# Altium schematic sheet 07-KSZ9031_1G_BASET_XCVR.SchDoc(07-KSZ9031_1G_BASET_XCVR)
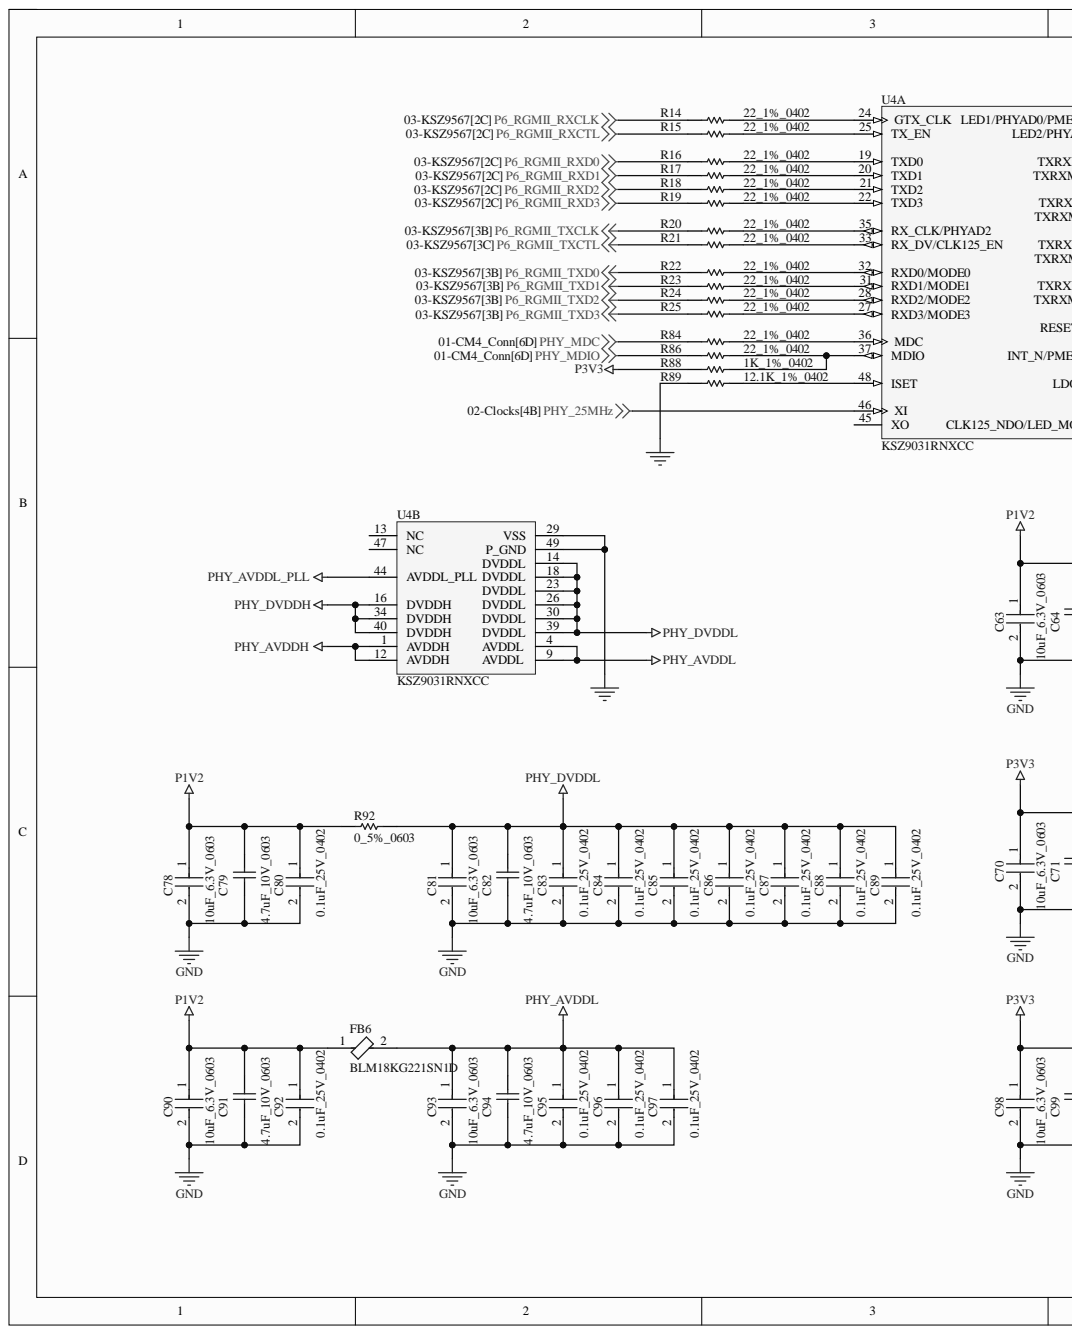
[diagram p0: 07-KSZ9031_1G_BASET_XCVR.SchDoc, left]
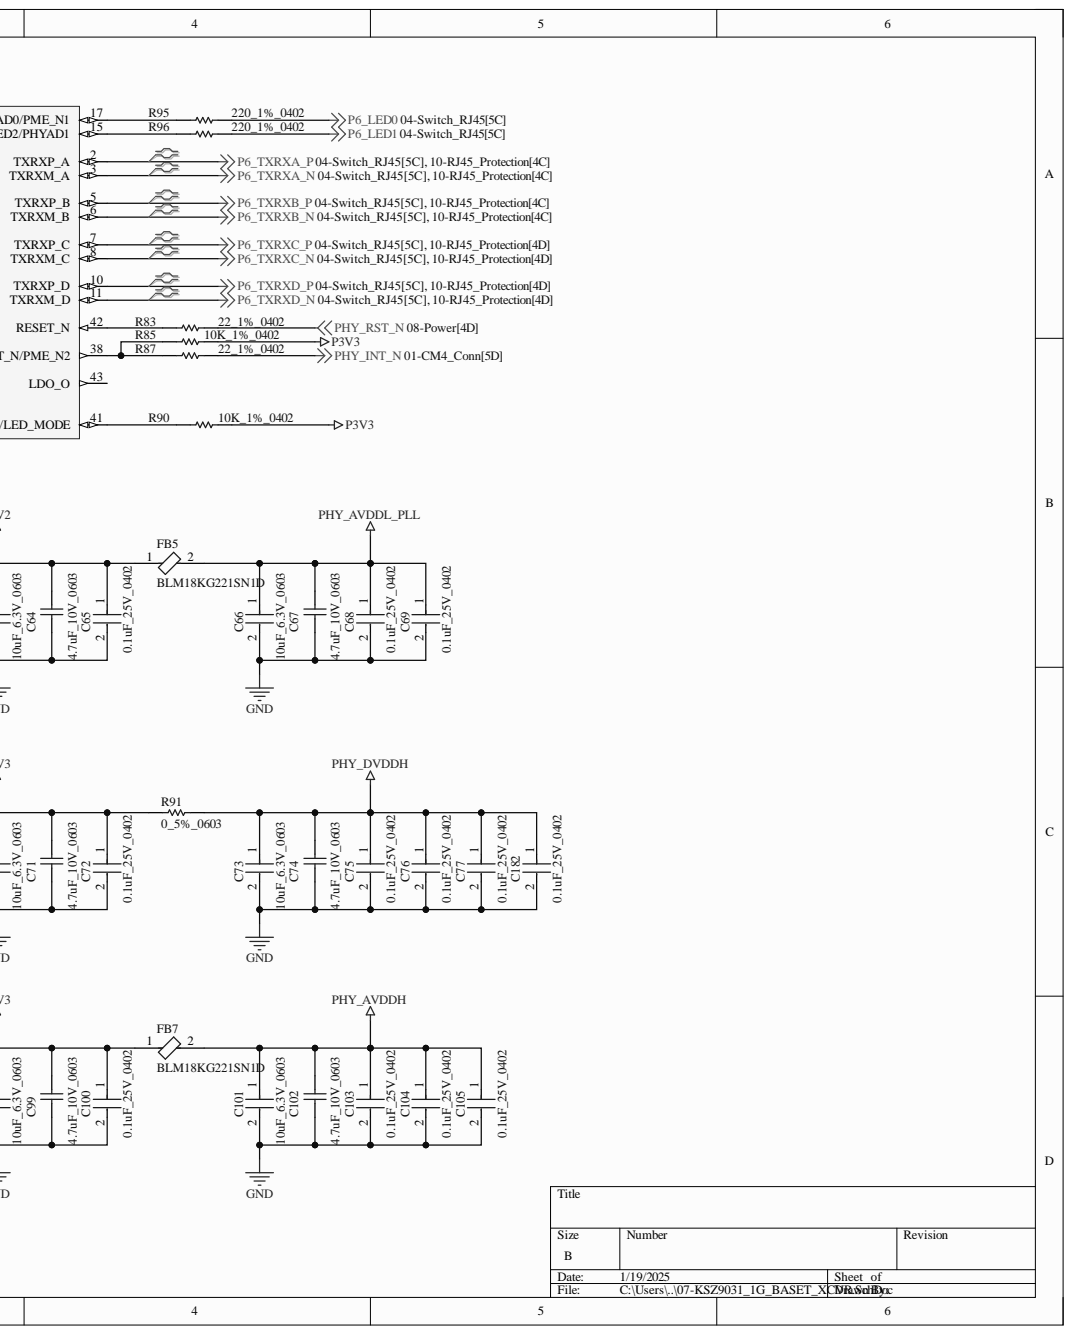
[diagram p1: 07-KSZ9031_1G_BASET_XCVR.SchDoc, right]

## Parts
C63  10uF_6.3V_0603  [FP-C0603C106M9PACTU-MFG]
C64  4.7uF_10V_0603  [CAPC1608X87X35NL15T15]
C65  0.1uF_25V_0402  [FP-0402-L_1_0_1-W_0_5_0_1-IPC_C]
C66  10uF_6.3V_0603  [FP-C0603C106M9PACTU-MFG]
C67  4.7uF_10V_0603  [CAPC1608X87X35NL15T15]
C68  0.1uF_25V_0402  [FP-0402-L_1_0_1-W_0_5_0_1-IPC_C]
C69  0.1uF_25V_0402  [FP-0402-L_1_0_1-W_0_5_0_1-IPC_C]
C70  10uF_6.3V_0603  [FP-C0603C106M9PACTU-MFG]
C71  4.7uF_10V_0603  [CAPC1608X87X35NL15T15]
C72  0.1uF_25V_0402  [FP-0402-L_1_0_1-W_0_5_0_1-IPC_C]
C73  10uF_6.3V_0603  [FP-C0603C106M9PACTU-MFG]
C74  4.7uF_10V_0603  [CAPC1608X87X35NL15T15]
C75  0.1uF_25V_0402  [FP-0402-L_1_0_1-W_0_5_0_1-IPC_C]
C76  0.1uF_25V_0402  [FP-0402-L_1_0_1-W_0_5_0_1-IPC_C]
C77  0.1uF_25V_0402  [FP-0402-L_1_0_1-W_0_5_0_1-IPC_C]
C78  10uF_6.3V_0603  [FP-C0603C106M9PACTU-MFG]
C79  4.7uF_10V_0603  [CAPC1608X87X35NL15T15]
C80  0.1uF_25V_0402  [FP-0402-L_1_0_1-W_0_5_0_1-IPC_C]
C81  10uF_6.3V_0603  [FP-C0603C106M9PACTU-MFG]
C82  4.7uF_10V_0603  [CAPC1608X87X35NL15T15]
C83  0.1uF_25V_0402  [FP-0402-L_1_0_1-W_0_5_0_1-IPC_C]
C84  0.1uF_25V_0402  [FP-0402-L_1_0_1-W_0_5_0_1-IPC_C]
C85  0.1uF_25V_0402  [FP-0402-L_1_0_1-W_0_5_0_1-IPC_C]
C86  0.1uF_25V_0402  [FP-0402-L_1_0_1-W_0_5_0_1-IPC_C]
C87  0.1uF_25V_0402  [FP-0402-L_1_0_1-W_0_5_0_1-IPC_C]
C88  0.1uF_25V_0402  [FP-0402-L_1_0_1-W_0_5_0_1-IPC_C]
C89  0.1uF_25V_0402  [FP-0402-L_1_0_1-W_0_5_0_1-IPC_C]
C90  10uF_6.3V_0603  [FP-C0603C106M9PACTU-MFG]
C91  4.7uF_10V_0603  [CAPC1608X87X35NL15T15]
C92  0.1uF_25V_0402  [FP-0402-L_1_0_1-W_0_5_0_1-IPC_C]
C93  10uF_6.3V_0603  [FP-C0603C106M9PACTU-MFG]
C94  4.7uF_10V_0603  [CAPC1608X87X35NL15T15]
C95  0.1uF_25V_0402  [FP-0402-L_1_0_1-W_0_5_0_1-IPC_C]
C96  0.1uF_25V_0402  [FP-0402-L_1_0_1-W_0_5_0_1-IPC_C]
C97  0.1uF_25V_0402  [FP-0402-L_1_0_1-W_0_5_0_1-IPC_C]
C98  10uF_6.3V_0603  [FP-C0603C106M9PACTU-MFG]
C99  4.7uF_10V_0603  [CAPC1608X87X35NL15T15]
C100  0.1uF_25V_0402  [FP-0402-L_1_0_1-W_0_5_0_1-IPC_C]
C101  10uF_6.3V_0603  [FP-C0603C106M9PACTU-MFG]
C102  4.7uF_10V_0603  [CAPC1608X87X35NL15T15]
C103  0.1uF_25V_0402  [FP-0402-L_1_0_1-W_0_5_0_1-IPC_C]
C104  0.1uF_25V_0402  [FP-0402-L_1_0_1-W_0_5_0_1-IPC_C]
C105  0.1uF_25V_0402  [FP-0402-L_1_0_1-W_0_5_0_1-IPC_C]
C182  0.1uF_25V_0402  [FP-0402-L_1_0_1-W_0_5_0_1-IPC_C]
FB5  BLM18KG221SN1D  [FP-BLM18-0_15-t0_8-IPC_B]
FB6  BLM18KG221SN1D  [FP-BLM18-0_15-t0_8-IPC_B]
FB7  BLM18KG221SN1D  [FP-BLM18-0_15-t0_8-IPC_B]
R14  22_1%_0402  [RESC1005X40X25ML05T10]
R15  22_1%_0402  [RESC1005X40X25ML05T10]
R16  22_1%_0402  [RESC1005X40X25ML05T10]
R17  22_1%_0402  [RESC1005X40X25ML05T10]
R18  22_1%_0402  [RESC1005X40X25ML05T10]
R19  22_1%_0402  [RESC1005X40X25ML05T10]
R20  22_1%_0402  [RESC1005X40X25ML05T10]
R21  22_1%_0402  [RESC1005X40X25ML05T10]
R22  22_1%_0402  [RESC1005X40X25ML05T10]
R23  22_1%_0402  [RESC1005X40X25ML05T10]
R24  22_1%_0402  [RESC1005X40X25ML05T10]
R25  22_1%_0402  [RESC1005X40X25ML05T10]
R83  22_1%_0402  [RESC1005X40X25ML05T10]
R84  22_1%_0402  [RESC1005X40X25ML05T10]
R85  10K_1%_0402  [RESC1005X40X25NL10T10]
R86  22_1%_0402  [RESC1005X40X25ML05T10]
R87  22_1%_0402  [RESC1005X40X25ML05T10]
R88  1K_1%_0402  [RESC1005X40X25ML05T10]
R89  12.1K_1%_0402  [RESC1005X40X25NL10T10]
R90  10K_1%_0402  [RESC1005X40X25NL10T10]
R91  0_5%_0603  [RESC1608X55X25ML10T15]
R92  0_5%_0603  [RESC1608X55X25ML10T15]
R95  220_1%_0402  [RESC1005X40X25NL05T10]
R96  220_1%_0402  [RESC1005X40X25NL05T10]
U4  KSZ9031RNXCC  [MICL-QFN-48_V]  (parts A,B)

## Nets
GND: C63.2 C64.1 C65.2 C66.2 C67.1 C68.2 C69.2 C70.2 C71.1 C72.2 C73.2 C74.1 C75.2 C76.2 C77.2 C78.2 C79.1 C80.2 C81.2 C82.1 C83.2 C84.2 C85.2 C86.2 C87.2 C88.2 C89.2 C90.2 C91.1 C92.2 C93.2 C94.1 C95.2 C96.2 C97.2 C98.2 C99.1 C100.2 C101.2 C102.1 C103.2 C104.2 C105.2 C182.2 R89.1 U4.29(VSS) U4.49(P_GND)
P1V2: C63.1 C64.2 C65.1 C78.1 C79.2 C80.1 C90.1 C91.2 C92.1 FB5.1 FB6.1 R92.1
P3V3: C70.1 C71.2 C72.1 C98.1 C99.2 C100.1 FB7.1 R85.2 R88.1 R90.2 R91.1
P6_LED0: R95.2
P6_LED1: R96.2
P6_RGMII_RXCLK: R14.1
P6_RGMII_RXCTL: R15.1
P6_RGMII_RXD0: R16.1
P6_RGMII_RXD1: R17.1
P6_RGMII_RXD2: R18.1
P6_RGMII_RXD3: R19.1
P6_RGMII_TXCLK: R20.1
P6_RGMII_TXCTL: R21.1
P6_RGMII_TXD0: R22.1
P6_RGMII_TXD1: R23.1
P6_RGMII_TXD2: R24.1
P6_RGMII_TXD3: R25.1
P6_TXRXA_N: U4.3(TXRXM_A)
P6_TXRXA_P: U4.2(TXRXP_A)
P6_TXRXB_N: U4.6(TXRXM_B)
P6_TXRXB_P: U4.5(TXRXP_B)
P6_TXRXC_N: U4.8(TXRXM_C)
P6_TXRXC_P: U4.7(TXRXP_C)
P6_TXRXD_N: U4.11(TXRXM_D)
P6_TXRXD_P: U4.10(TXRXP_D)
PHY_25MHz: U4.46(XI)
PHY_AVDDH: C101.1 C102.2 C103.1 C104.1 C105.1 FB7.2 U4.1(AVDDH) U4.12(AVDDH)
PHY_AVDDL: C93.1 C94.2 C95.1 C96.1 C97.1 FB6.2 U4.4(AVDDL) U4.9(AVDDL)
PHY_AVDDL_PLL: C66.1 C67.2 C68.1 C69.1 FB5.2 U4.44(AVDDL_PLL)
PHY_DVDDH: C73.1 C74.2 C75.1 C76.1 C77.1 C182.1 R91.2 U4.16(DVDDH) U4.34(DVDDH) U4.40(DVDDH)
PHY_DVDDL: C81.1 C82.2 C83.1 C84.1 C85.1 C86.1 C87.1 C88.1 C89.1 R92.2 U4.14(DVDDL) U4.18(DVDDL) U4.23(DVDDL) U4.26(DVDDL) U4.30(DVDDL) U4.39(DVDDL)
PHY_INT_N: R87.2
PHY_MDC: R84.1
PHY_MDIO: R86.1
PHY_RST_N: R83.2
NetR14_2: R14.2 U4.24(GTX_CLK)
NetR15_2: R15.2 U4.25(TX_EN)
NetR16_2: R16.2 U4.19(TXD0)
NetR17_2: R17.2 U4.20(TXD1)
NetR18_2: R18.2 U4.21(TXD2)
NetR19_2: R19.2 U4.22(TXD3)
NetR20_2: R20.2 U4.35(RX_CLK/PHYAD2)
NetR21_2: R21.2 U4.33(RX_DV/CLK125_EN)
NetR22_2: R22.2 U4.32(RXD0/MODE0)
NetR23_2: R23.2 U4.31(RXD1/MODE1)
NetR24_2: R24.2 U4.28(RXD2/MODE2)
NetR25_2: R25.2 U4.27(RXD3/MODE3)
NetR83_1: R83.1 U4.42(RESET_N)
NetR84_2: R84.2 U4.36(MDC)
NetR85_1: R85.1 R87.1 U4.38(INT_N/PME_N2)
NetR86_2: R86.2 R88.2 U4.37(MDIO)
NetR89_2: R89.2 U4.48(ISET)
NetR90_1: R90.1 U4.41(CLK125_NDO/LED_MODE)
NetR95_1: R95.1 U4.17(LED1/PHYAD0/PME_N1)
NetR96_1: R96.1 U4.15(LED2/PHYAD1)

Not connected: U4 13(NC) 43(LDO_O) 45(XO) 47(NC)

## Library data of the parts
C63 C66 C70 C73 C78 C81 C90 C93 C98 C101 = CMP-2006-02285-3: CAP CER 10UF 6.3V X5R 0603; Manufacturer: Kemet; Manufacturer Part Number: C0603C106M9PACTU; Material: Ceramic; Temperature Coefficient: 15%; Tolerance: 20%; Automotive: No; Capacitance: 10uF; Category: Cap; Component Kind: Standard; ComponentLink2Description: Datasheet; ComponentLink2URL: http://www.kemet.com/Lists/ProductCatalog/Attachments/19/KEM_C1006_X5R_SMD.pdf; Device Class L1: Passive Components; Device Class L2: Capacitors; Device Class L3: Ceramic Capacitors; Digikey Description: CAP CER 10UF 6.3V X5R 0603; Height: 0.9mm; Ipc Land Pattern Name: CAPC16080X80; Lead Free: Yes; Library Name: Altium Content Vault; Mouser Description: In a Pack of 10, KEMET 0603 (1608M) 10µF MLCC 6.3V dc ±20% SMD C0603C106M9PACTU; Octopart: https://octopart.com/c0603c106m9pactu-kemet-8375727; Package: C0603C106M9PACTU; RoHS: TRUE; Temperature: -55°C to +85°C; Temperature Characteristic: X5R; Temperature Range High: +85°C; Temperature Range Low: -55°C; Value: 10uF; Voltage Rating: 6.3V
C64 C67 C71 C74 C79 C82 C91 C94 C99 C102 = CMP-2006-03238-1: Manufacturer: Kemet; Part Number: C0603C475K8PACTU; Component Kind: Standard; Library Name: Altium Content Vault
C65 C68 C69 C72 C75-C77 C80 C83-C89 C92 C95-C97 C100 C103-C105 C182 = CMP-2008-02519-2: General Purpose Ceramic Capacitor, 0402, 100nF, 10%, X7R, 15%, 25V; Capacitance: 100nF; Case Code (Imperial): 0402; Case Code (Metric): 1005; Case/Package: 0402; Component Kind: Standard; Construction: Flat; Depth: 0.5mm; Dielectric: X7R; Lead Free: Lead Free; Length: 1mm; Library Name: Altium Content Vault; Max Operating Temperature: 125°C; Min Operating Temperature: -55°C; Mount: Surface Mount; Number of Pins: 2; Package Quantity: 1; Packaging: Tape and Reel; Radiation Hardening: No; REACH SVHC: No SVHC; RoHS Compliant: Yes; Series: -; Termination: SMD/SMT; Thickness: 0.022inch; Tolerance: 10%; Voltage: 25V; Voltage Rating: 25V; Voltage Rating (DC): 25V; Width: 0.02inch
FB5-FB7 = CMP-0686-00556-7: Chip Ferrite Bead, 0603, 220Ω @ 100MHz, 0.05Ω, 25%, 2.2A; DC Resistance (DCR): 50mR; Impedance: 220R; Number of Circuits: 1; Case Code (Imperial): 0603; Case Code (Metric): 1608; Case/Package: 0603; Component Kind: Standard; Core Material: Ferrite; Current Rating: 2.2A; DC Current: 2.2A; DC Resistance-Max: 50mR; Depth: 0.8mm; Height: 0.8mm; Inductor Type: FERRITE BEAD; Lead Free: Lead Free; Length: 1.6mm; Library Name: Altium Content Vault; Material: Ferrite; Max Current Rating: 2.2A; Max DC Current: 2.2A; Max Operating Temperature: 125°C; Military Standard: Not; Min Operating Temperature: -55°C; Number of Lines: 1; Number of Pins: 2; Package Quantity: 1; Packaging: Tape and Reel; Radiation Hardening: No; REACH SVHC: No SVHC; RoHS Compliant: Yes; Series: BLM18K; Termination: SMD/SMT; Test Frequency: 100MHz; Tolerance: 25%; Weight: 7.1E-05oz; Width: 0.8mm
R14-R25 R83 R84 R86 R87 = CMP-2002-07182-1: Manufacturer: Yageo / Phycomp; Part Number: RC0402FR-1322RL; Component Kind: Standard; Library Name: Altium Content Vault
R85 R90 = CMP-2002-04694-1: Manufacturer: Bourns; Part Number: CR0402-FX-1002GLF; Component Kind: Standard; Library Name: Altium Content Vault
R88 = CMP-2002-06478-1: Manufacturer: Multicomp; Part Number: MCMR04X1001FTL; Component Kind: Standard; Library Name: Altium Content Vault
R89 = CMP-2002-05926-1: Manufacturer: Multicomp; Part Number: MC00625W0402112K1; Component Kind: Standard; Library Name: Altium Content Vault
R91 R92 = CMP-2100-03667-1: Chip Resistor, 0 Ohm, +/- 5%, 0.1 W, -55 to 155 degC, 0603 (1608 Metric), RoHS, Tape and Reel; Manufacturer: Yageo; Power Rating: 100mW; Resistance: 0mOhm; Temperature Coefficient: 200ppm/degreesC; Voltage Rating: 50V; Case Code (Imperial): 0603; Case Code (Metric): 1608; Case/Package: 0603; Component Kind: Standard; Composition: ThickFilm; Contact Plating: Tin; Datasheet URL: http://www.yageo.com/NewPortal/yageodocoutput?fileName=/pdf/R-Chip/PYu-RC_Group_51_RoHS_L_5.pdf; Datasheet Version: Rev. 5, 10/2016; Depth: 0.8mm; Height: 0.45mm; Lead Free: LeadFree; Length: 1.6mm; Library Name: Altium Content Vault; Manufacturer URL: http://www.yageo.com/; Max Operating Temperature: 155degC; Military Standard: Not; Min Operating Temperature: -55degC; Number of Pins: 2; Package Description: 2-Pin Surface Mount Device, Body 1.6 x 0.8 mm; Package Quantity: 5000; Package Reference: 0603; Packaging: TapeandReel; Radiation Hardening: No; REACH SVHC: NoSVHC; RoHSCompliant: true; Termination: SMD/SMT; Tolerance: 5%; Voltage Rating (DC): 50V; Width: 0.8mm
R95 R96 = CMP-2002-07959-1: Manufacturer: Yageo / Phycomp; Part Number: RC0402JR-07220RL; Component Kind: Standard; Library Name: Altium Content Vault
U4 = CMP-1337-00003-2: Gigabit Ethernet Transceiver with RGMII Support, 1.14 to 1.26 V, 0 to 70 degC, 48-Pin QFN, RoHS; Manufacturer: Micrel; Drivers: 4; ILED: 8 mA Typ.; Output Tri-State Leakage: 10 uA Max.; VSET@R(ISET): 12.1 KOhm=1.2 V Typ.; Component Kind: Standard; ComponentLink1Description: Manufacturer URL; ComponentLink1URL: http://www.micrel.com/; ComponentLink2Description: Datasheet; ComponentLink2URL: http://www.micrel.com/_PDF/Ethernet/datasheets/KSZ9031RNX.pdf; ComponentLink3Description: Package Specification; ComponentLink3URL: http://www.micrel.com/_PDF/Ethernet/datasheets/KSZ9031RNX.pdf; DatasheetVersion: Rev. 2.1; DVDDL: 1.14 to 1.26 V; Library Name: Altium Content Vault; Mounting Technology: Surface Mount; PackageDescription: 48-Pin Quad Flat Non-Lead Package, Body 7 x 7 mm, Pitch 0.5 mm; PartNumber: KSZ9031RNXCC; Receivers: 4; RoHS: TRUE; Temperature Range: 0 to 70 degC
